# Altium schematic sheet CONNECTION.SchDoc
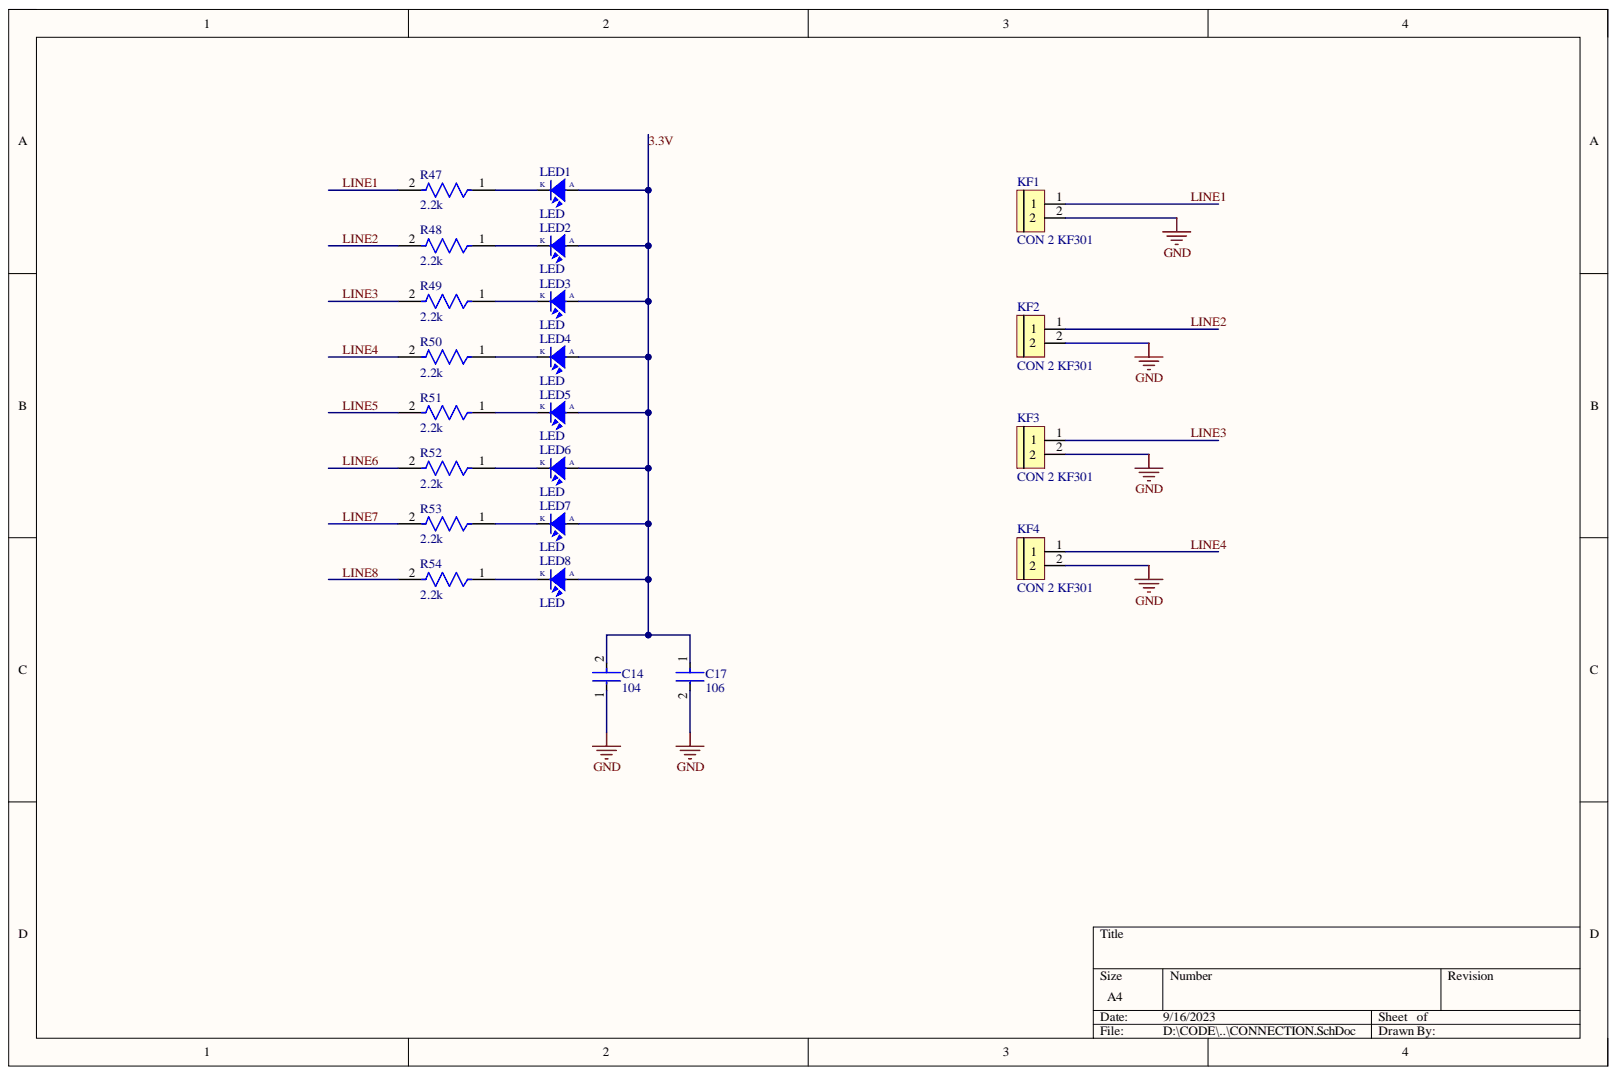
[diagram p0: CONNECTION.SchDoc]

## Parts
C14  104  [FP-GRM15-MFG]
C17  106  [FP-GRM188-0_1-e0_2_0_55-IPC_A]
KF1  CON 2 KF301  [Con 2 KF301 5.08]
KF2  CON 2 KF301  [Con 2 KF301 5.08]
KF3  CON 2 KF301  [Con 2 KF301 5.08]
KF4  CON 2 KF301  [Con 2 KF301 5.08]
LED1  LED  [LED0603]
LED2  LED  [LED0603]
LED3  LED  [LED0603]
LED4  LED  [LED0603]
LED5  LED  [LED0603]
LED6  LED  [LED0603]
LED7  LED  [LED0603]
LED8  LED  [LED0603]
R47  2.2k  [FP-ERJ2RK-MFG]
R48  2.2k  [FP-ERJ2RK-MFG]
R49  2.2k  [FP-ERJ2RK-MFG]
R50  2.2k  [FP-ERJ2RK-MFG]
R51  2.2k  [FP-ERJ2RK-MFG]
R52  2.2k  [FP-ERJ2RK-MFG]
R53  2.2k  [FP-ERJ2RK-MFG]
R54  2.2k  [FP-ERJ2RK-MFG]

## Nets
GND: C14.1 C17.2 KF1.2 KF2.2 KF3.2 KF4.2
3.3V: C14.2 C17.1 LED1.1 LED2.1 LED3.1 LED4.1 LED5.1 LED6.1 LED7.1 LED8.1
LINE1: KF1.1 R47.2
LINE2: KF2.1 R48.2
LINE3: KF3.1 R49.2
LINE4: KF4.1 R50.2
LINE5: R51.2
LINE6: R52.2
LINE7: R53.2
LINE8: R54.2
NetLED1_2: LED1.2 R47.1
NetLED2_2: LED2.2 R48.1
NetLED3_2: LED3.2 R49.1
NetLED4_2: LED4.2 R50.1
NetLED5_2: LED5.2 R51.1
NetLED6_2: LED6.2 R52.1
NetLED7_2: LED7.2 R53.1
NetLED8_2: LED8.2 R54.1

## Library data of the parts
C14 = CMP-2100-03641-2: CAP CER 0.1UF 16V X7R 0402; Manufacturer: Murata; Manufacturer Part Number: GRM155R71C104KA88D; Capacitance: 0.10μF; Material: Ceramic; Standoff Height: 0mm; Tolerance: 10%; Value: 0.10μF; Voltage Rating: 16Vdc; Category: Cap; Component Kind: Standard; ComponentLink2Description: Datasheet; ComponentLink2URL: https://search.murata.co.jp/Ceramy/image/img/A01X/G101/ENG/GRM155R71H471KA01-01A.pdf; Device Class L1: Passive Components; Device Class L2: Capacitors; Device Class L3: Ceramic Capacitors; Digikey Description: CAP CER 0.1UF 16V X7R 0402; Height: 0.55mm; Ipc Land Pattern Name: CAPC10050X219; Lead Free: yes; Library Name: Altium Content Vault; Mouser Description: 0402 0.1 uF 16V ±10 % Tolerance X7R SMT Multilayer Ceramic Capacitor; Octopart: https://octopart.com/grm155r71c104ka88d-murata-196880; Package: GRM15; RoHS: TRUE; Temperature: -55°C to +125°C; Temperature Characteristic: X7R; Temperature Range High: +125°C; Temperature Range Low: -55°C
C17 = CMP-2006-02792-2: Chip Multilayer Ceramic Capacitors for General Purpose, 0603, 10uF, X5R, 15%, 20%, 6.3V; Capacitance: 10uF; Case Code (Imperial): 0603; Case Code (Metric): 1608; Case/Package: 0603; Component Kind: Standard; Depth: 0.8mm; Dielectric: X5R; Height: 0.8mm; Lead Free: Lead Free; Length: 1.6mm; Library Name: Altium Content Vault; Material: Ceramic; Max Operating Temperature: 85°C; Min Operating Temperature: -55°C; Mount: Surface Mount; Package Quantity: 4000; Packaging: Tape and Reel; Radiation Hardening: No; REACH SVHC: No SVHC; Termination: SMD/SMT; Thickness: 0.035inch; Tolerance: 20%; Voltage: 6.3V; Voltage Rating: 6.3V; Voltage Rating (DC): 6.3V; Width: 0.031inch
KF1-KF4 = CON 2 KF301: Cắm; Ma_Hang: MH000987; Ten_Linh_Kien: CON 2 KF301-2P 5.08MM Xanh Dương; Chung_Loai: Cắm; Component Kind: Standard; Don_Vi: Con; Library Name: Connector.SchLib
LED1-LED8 = LED: LED Single colour Green and Red; Value: LED 3 ly Single Colour; Component Kind: Standard; Library Name: PART (1).IntLib
R47-R54 = CMP-2002-00608-4: RES SMD 100K OHM 1% 1/10W 0402; Max Power Dissipation: 100mW; Resistance: 100kR; Temperature Coefficient: 100ppm/°C; Voltage Rating: 50V; Case Code (Imperial): 0402; Case Code (Metric): 1005; Case/Package: 0402; Component Kind: Standard; Composition: Thick Film; Contact Plating: Tin; Depth: 0.5mm; Features: Automotive AEC-Q200; Height: 0.35mm; Lead Free: Lead Free; Length: 1mm; Library Name: Altium Content Vault; Max Operating Temperature: 155°C; Military Standard: Not; Min Operating Temperature: -55°C; Mount: Surface Mount; Number of Pins: 2; Number of Terminations: 2; Package Quantity: 1; Packaging: Tape and Reel; Power Rating: 100mW; Radiation Hardening: No; REACH SVHC: No SVHC; Termination: SMD/SMT; Tolerance: 1%; Voltage Rating (DC): 50V; Width: 0.5mm
